# Altium schematic sheet P04_GSM 1 of 2.SchDoc
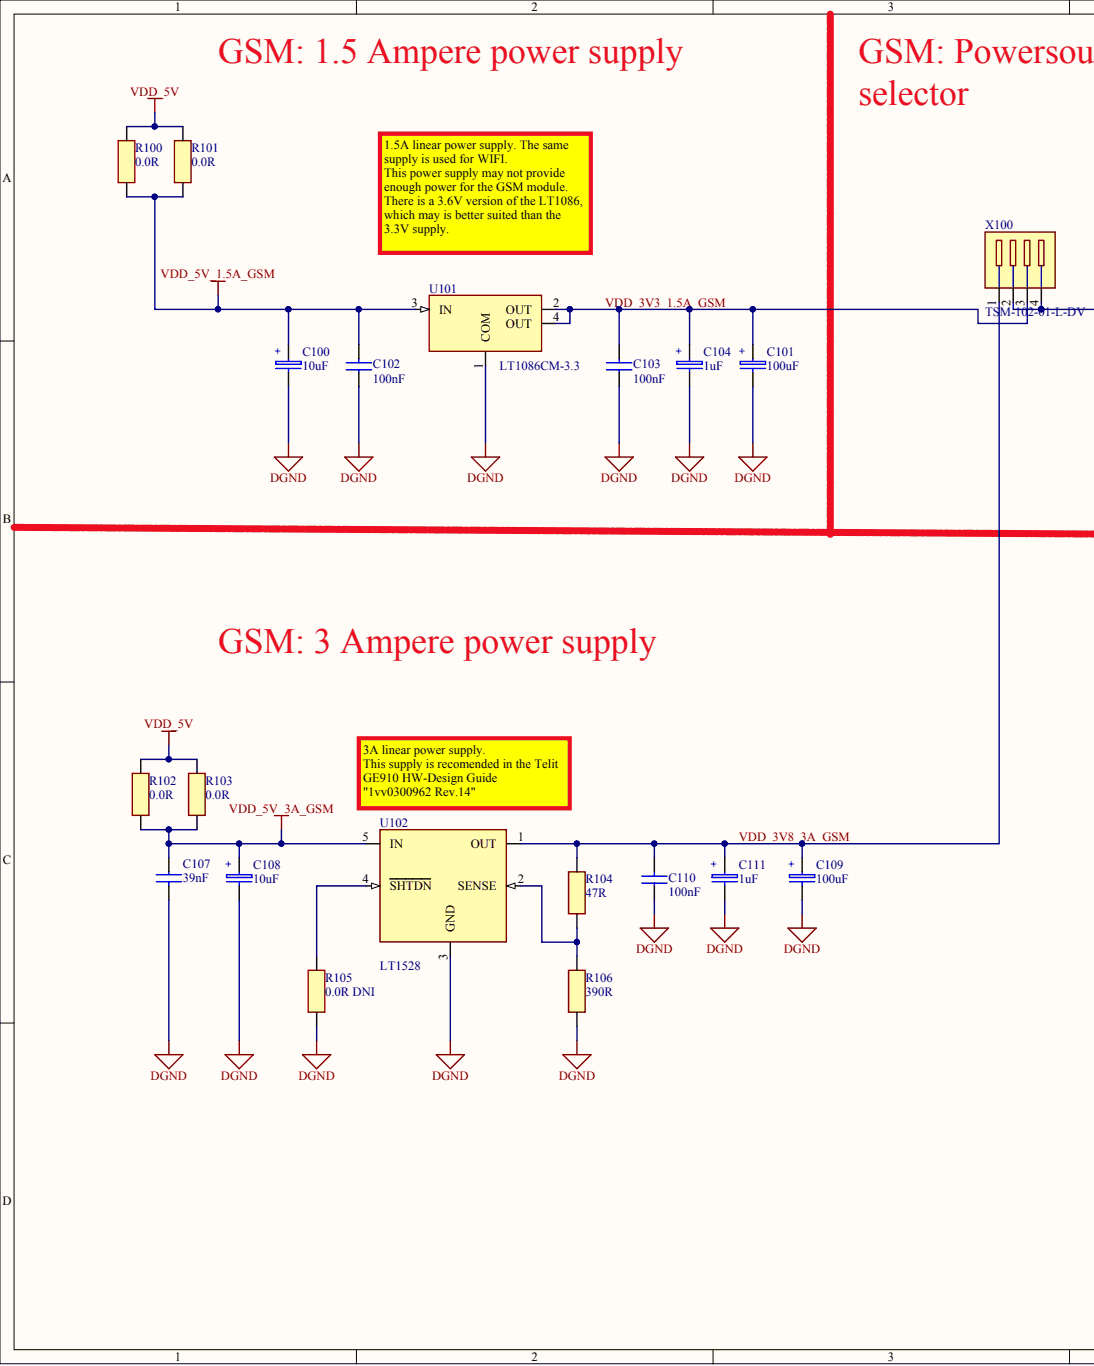
[diagram p0: P04_GSM 1 of 2.SchDoc, left]
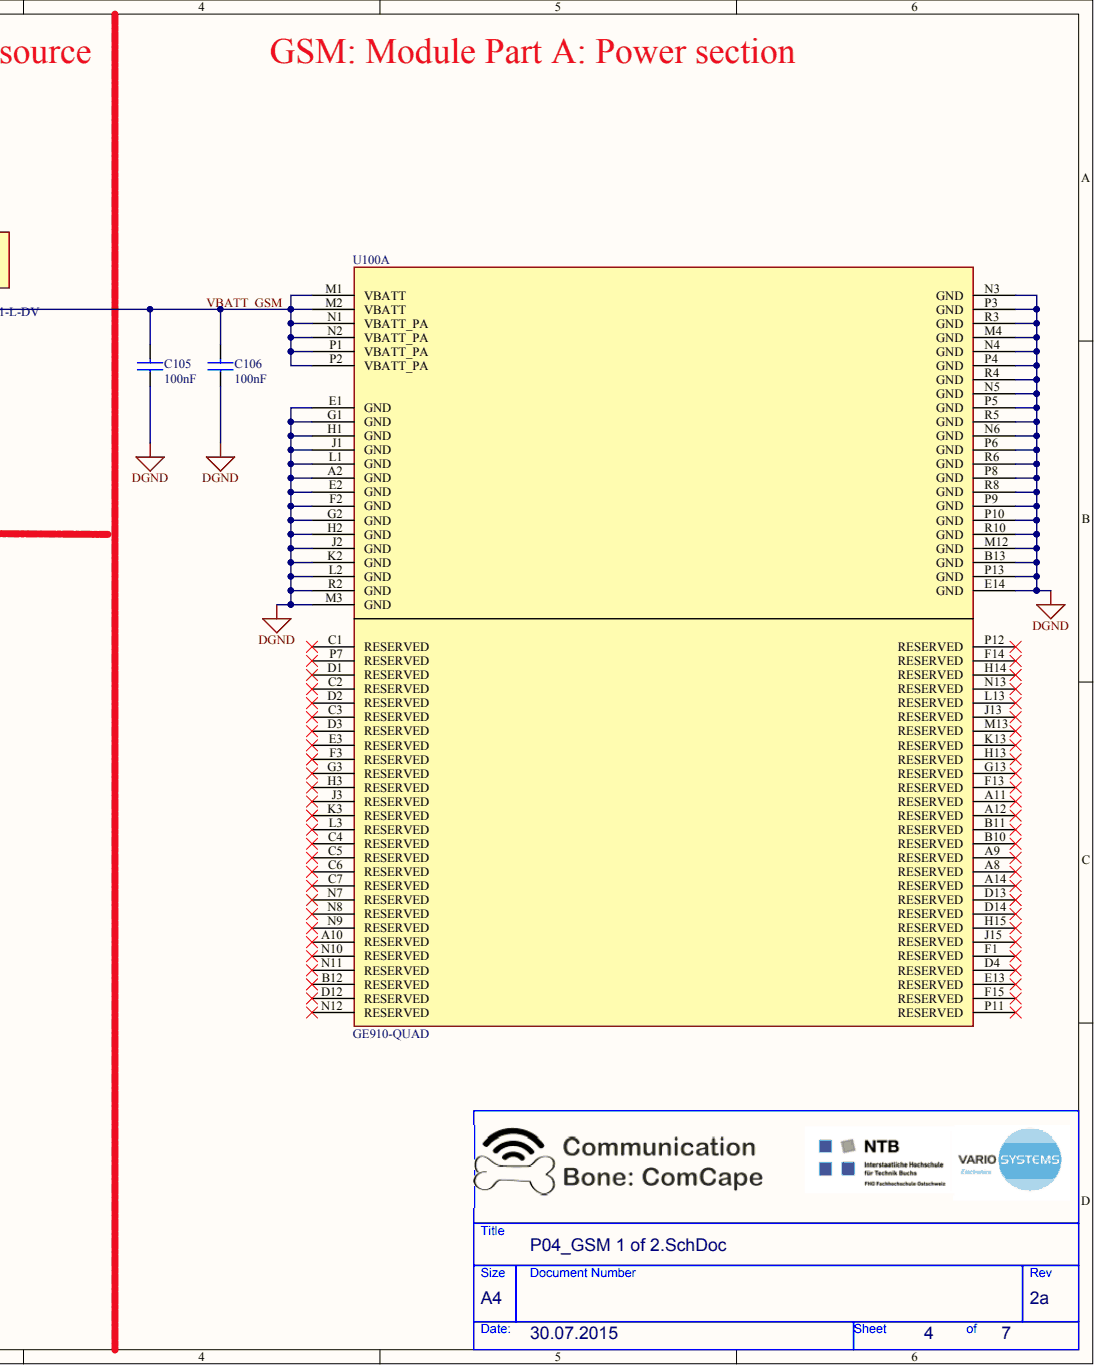
[diagram p1: P04_GSM 1 of 2.SchDoc, right]

## Parts
C100  10uF  [SM/CP_3528]
C101  100uF  [SM/CP_6032]
C102  100nF  [SM/C_0603]
C103  100nF  [SM/C_0603]
C104  1uF  [SM/CP_3216]
C105  100nF  [SM/C_0603]
C106  100nF  [SM/C_0603]
C107  39nF  [SM/C_0603]
C108  10uF  [SM/CP_3528]
C109  100uF  [SM/CP_6032]
C110  100nF  [SM/C_0603]
C111  1uF  [SM/CP_3216]
R100  0.0R  [SM/R_0603]
R101  0.0R  [SM/R_0603]
R102  0.0R  [SM/R_0603]
R103  0.0R  [SM/R_0603]
R104  47R  [SM/R_0603]
R105  0.0R DNI  value 0.0R  [SM/R_0603]
R106  390R  [SM/R_0603]
U100  GE910-QUAD  [LGA144C180P15X15_2820X2820X225]  (part A)
U101  LT1086CM-3.3  [SM/DDPAK]
U102  LT1528  [SM/TO263-5]
X100  TSM-102-01-L-DV  [STILEI.100/2X2_SM]

## Nets
DGND: C100.N C101.N C102.1 C103.1 C104.N C105.1 C106.1 C107.2 C108.N C109.N C110.1 C111.N R105.2 R106.2 U100.A2(GND) U100.B13(GND) U100.E1(GND) U100.E2(GND) U100.E14(GND) U100.F2(GND) U100.G1(GND) U100.G2(GND) U100.H1(GND) U100.H2(GND) U100.J1(GND) U100.J2(GND) U100.K2(GND) U100.L1(GND) U100.L2(GND) U100.M3(GND) U100.M4(GND) U100.M12(GND) U100.N3(GND) U100.N4(GND) U100.N5(GND) U100.N6(GND) U100.P3(GND) U100.P4(GND) U100.P5(GND) U100.P6(GND) U100.P8(GND) U100.P9(GND) U100.P10(GND) U100.P13(GND) U100.R2(GND) U100.R3(GND) U100.R4(GND) U100.R5(GND) U100.R6(GND) U100.R8(GND) U100.R10(GND) U101.1(COM) U102.3(GND)
VDD_5V: R100.2 R101.2 R102.2 R103.2
VDD_5V_1.5A_GSM: C100.P C102.2 R100.1 R101.1 U101.3(IN)
VDD_5V_3A_GSM: C107.1 C108.P R102.1 R103.1 U102.5(IN)
VBATT_GSM: C105.2 C106.2 U100.M1(VBATT) U100.M2(VBATT) U100.N1(VBATT_PA) U100.N2(VBATT_PA) U100.P1(VBATT_PA) U100.P2(VBATT_PA) X100.2 X100.4
VDD_3V3_1.5A_GSM: C101.P C103.2 C104.P U101.2(OUT) U101.4(OUT) X100.3
VDD_3V8_3A_GSM: C109.P C110.2 C111.P R104.1 U102.1(OUT) X100.1
NetR104_2: R104.2 R106.1 U102.2(SENSE)
NetR105_1: R105.1 U102.4(SHTDN)

Not connected: U100 A8(RESERVED) A9(RESERVED) A10(RESERVED) A11(RESERVED) A12(RESERVED) A14(RESERVED) B10(RESERVED) B11(RESERVED) B12(RESERVED) C1(RESERVED) C2(RESERVED) C3(RESERVED) C4(RESERVED) C5(RESERVED) C6(RESERVED) C7(RESERVED) D1(RESERVED) D2(RESERVED) D3(RESERVED) D4(RESERVED) D12(RESERVED) D13(RESERVED) D14(RESERVED) E3(RESERVED) E13(RESERVED) F1(RESERVED) F3(RESERVED) F13(RESERVED) F14(RESERVED) F15(RESERVED) G3(RESERVED) G13(RESERVED) H3(RESERVED) H13(RESERVED) H14(RESERVED) H15(RESERVED) J3(RESERVED) J13(RESERVED) J15(RESERVED) K3(RESERVED) K13(RESERVED) L3(RESERVED) L13(RESERVED) M13(RESERVED) N7(RESERVED) N8(RESERVED) N9(RESERVED) N10(RESERVED) N11(RESERVED) N12(RESERVED) N13(RESERVED) P7(RESERVED) P11(RESERVED) P12(RESERVED)

## Library data of the parts
C100 C108 = SC CAP __3528 _10u00 _10V ___20% TAN ___: Manufacturer: Generic Component; Part Number: 208069; Cust: Y; HTNr: take notice of key data; Tolerance: 20%; Voltage: 10V; Component Kind: Standard; Description: SC CAP __3528 _10u00 _10V ___20% TAN ___; Part Type: CAP-SMD\Tantal; Std: STD; Supplier 1: Digi-Key; Supplier Part Number 1: 399-3707-1-ND; Library Name: ComCape.SchLib
C101 C109 = SC CAP __6032 100u00 _10V ___10% TAN ___: Manufacturer: Generic Component; Part Number: 239171; Cust: Y; HTNr: take notice of key data; Tolerance: 10%; Voltage: 10V; Component Kind: Standard; Description: SC CAP __6032 100u00 _10V ___10% TAN ___; Part Type: CAP-SMD\Tantal; Std: STD; Supplier 1: Digi-Key; Supplier Part Number 1: 718-1055-1-ND; Library Name: ComCape.SchLib
C102 C103 C105 C106 C110 = (no symbol name): SC CAP __0603 100n00 _16V ___10% X7R ___; Manufacturer: Generic Component; Part Number: 209336; Cust: Y; HTNr: take notice of key data; Tolerance: 10%; Voltage: 16V; Component Kind: Standard; Part Type: CAP-SMD\Keramik\0603; PCB Footprint: SM/C_0603; Std: STD; Supplier 1: Digi-Key; Supplier Part Number 1: 399-1096-1-ND; Value: 100nF; Library Name: ComCape.SchLib
C104 C111 = SC CAP __3216 __1u00 _16V ___10% TAN ___: Manufacturer: Kemet; Part Number: 227555; Cust: Y; HTNr: T491A105K016AT; Tolerance: 10%; Voltage: 16V; Component Kind: Standard; Description: SC CAP __3216 __1u00 _16V ___10% TAN ___; Part Type: CAP-SMD\Tantal; Supplier 1: Digi-Key; Supplier Part Number 1: 399-3678-1-ND; Datasheet: S:\Datenb\DS-221192-000A.pdf; Library Name: ComCape.SchLib
C107 = SC CAP __0603 _39N00 _50V ___10% X7R ___: Manufacturer: Generic Component; Part Number: 252065; Cust: Y; HTNr: take notice of key data; Std: SET; Tolerance: 10%; Voltage: 50V; Component Kind: Standard; Description: SC CAP __0603 _39N00 _50V ___10% X7R ___; Part Type: CAP-SMD\Keramik\0603; Supplier 1: Digi-Key; Supplier Part Number 1: 490-6435-1-ND; Library Name: ComCape.SchLib
R100-R103 R105 = SC RES __0603 __0R00 __0W1 _____ T100 __: Manufacturer: Generic Component; Part Number: 206748; Cust: Y; HTNr: take notice of key data; Power: 0.1W; Std: STD SET; Tolerance: 1%; Component Kind: Standard; Description: SC RES __0603 __0R00 __0W1 _____ T100 __; Part Type: RES-SMD\0603; PCB Footprint: SM/R_0603; Supplier 1: Digi-Key; Supplier Part Number 1: 1276-3510-1-ND; Library Name: ComCape.SchLib
  R100-R103: Value: 0.0R
R104 = SC RES __0603 _47R00 __0W1 ___1% T100 __: Manufacturer: Generic Component; Part Number: 209764; Cust: Y; HTNr: take notice of key data; Power: 0.1W; Std: STD SET; Tolerance: 1%; Component Kind: Standard; Description: SC RES __0603 _47R00 __0W1 ___1% T100 __; Part Type: RES-SMD\0603; PCB Footprint: SM/R_0603; Supplier 1: Digi-Key; Supplier Part Number 1: 1276-4539-1-ND; Value: 47R; Library Name: ComCape.SchLib
R106 = SC RES __0603 390R00 __0W1 ___1% T100 __: Manufacturer: Generic Component; Part Number: 220449; Cust: Y; HTNr: take notice of key data; Power: 0.1W; Std: STD SET; Tolerance: 1%; Component Kind: Standard; Description: SC RES __0603 390R00 __0W1 ___1% T100 __; Part Type: RES-SMD\0603; PCB Footprint: SM/R_0603; Supplier 1: Digi-Key; Supplier Part Number 1: 1276-4610-1-ND; Value: 390R; Library Name: ComCape.SchLib
U100 = GE910-QUAD: GSM Module; Manufacturer: Telit; Component Kind: Standard; Mounting Type: Surface Mount; Package / Case: 144-LGA; RoHS: RoHS Compliant; Library Name: ComCape.SchLib
U101 = S_ IC_ ____D2PAK LT1086CM-3.3________ __: Manufacturer: Linear Technology; Part Number: 203098; Cust: Y; Component Kind: Standard; Description: S_ IC_ ____D2PAK LT1086CM-3.3________ __; HTNr: LT1086CM-3.3; Part Type: IC-Analog\Spannungsversorgung; Supplier 1: Digi-Key; Supplier Part Number 1: LT1086CM-3.3#PBF-ND; Datasheet: S:\Datenb\DS-203098-000A.pdf; Library Name: ComCape.SchLib
U102 = LT1528: 3A Low Dropout Regulator; Manufacturer: Linear Technology; HTNr: LT1528CQ#PBF; Component Kind: Standard; Datasheet: http://www.linear.com/docs/1350; DigiKey Part Number: LT1528CQ#PBF-ND; Supplier 1: Digi-Key; Supplier Part Number 1: LT1528CQ#PBF-ND; Library Name: ComCape.SchLib
X100 = (no symbol name): S_ PHE M ST ____ ___4P _2RO Au R2.54 ___; Manufacturer: Samtec; Part Number: 243215; Component Kind: Standard; HTNr: TSM-102-01-L-DV; Part Type: MISC-Connectors\Pin-Header; Supplier 1: Digi-Key; Supplier 2: Digi-Key; Supplier Part Number 1: WM9514-ND; Supplier Part Number 2: TSM-102-01-L-DV-ND; Datasheet: S:\Datenb\DS-226625-000B.pdf; Library Name: ComCape.SchLib
